# Altium schematic sheet Power.SchDoc(Power)
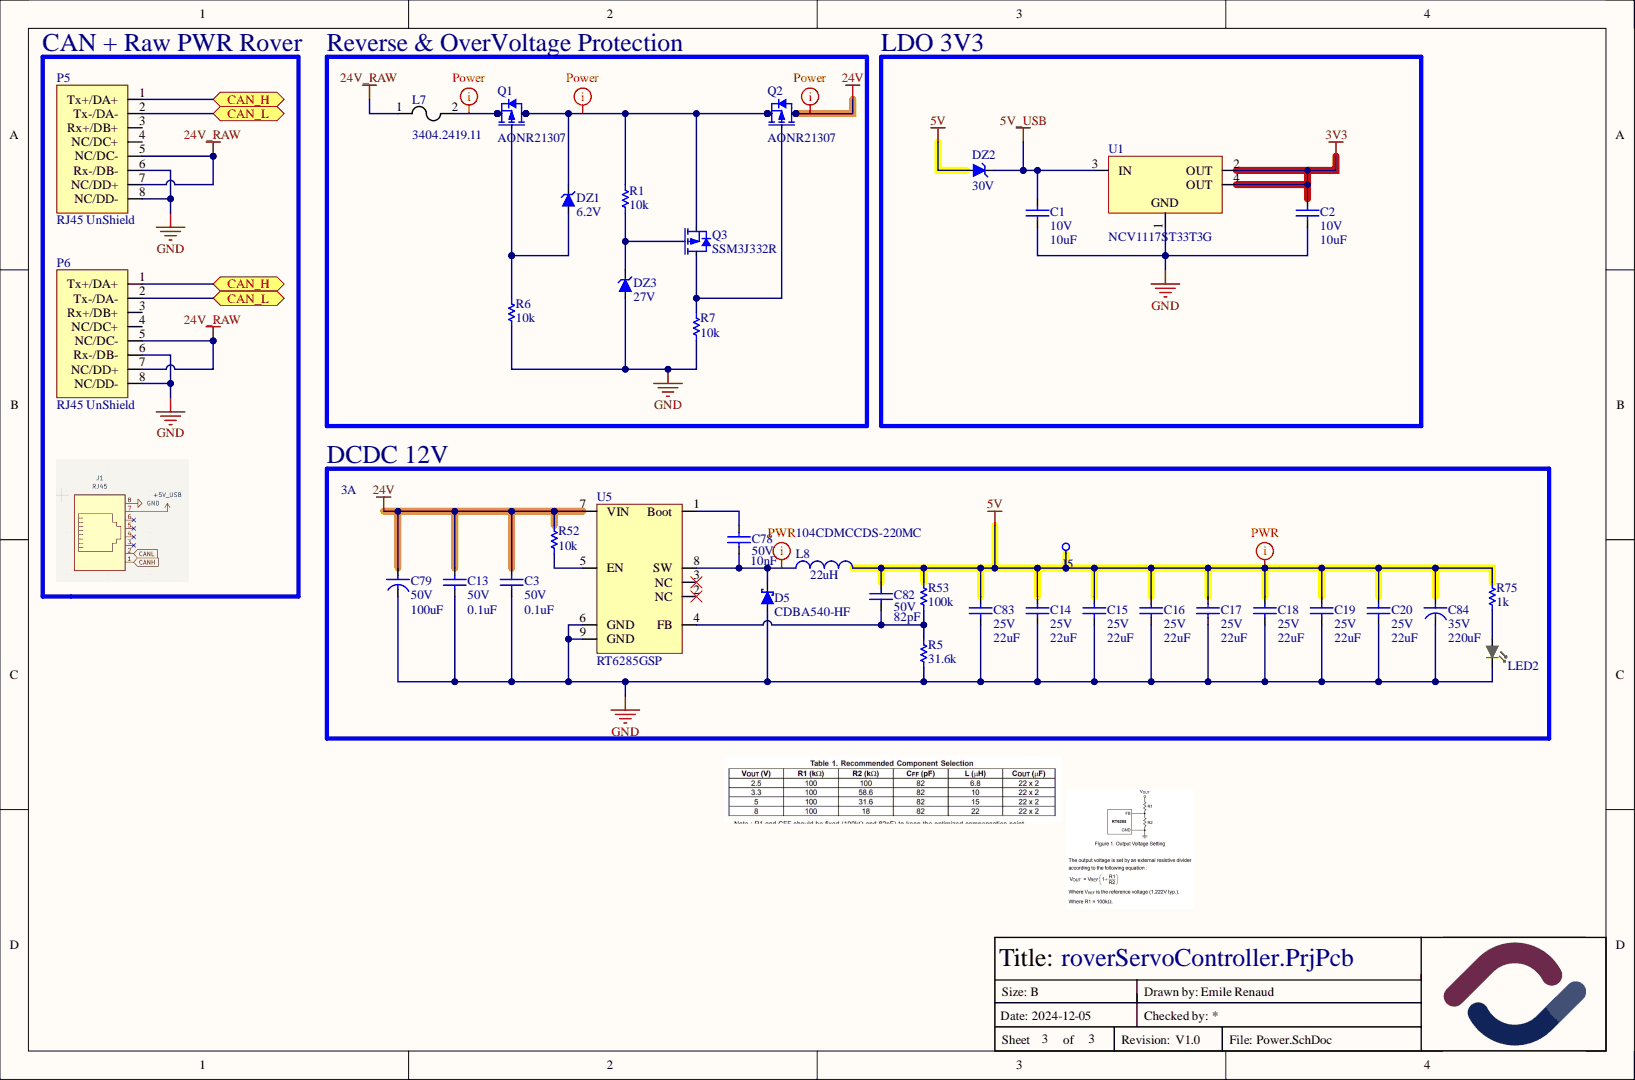
[diagram p0: Power.SchDoc]

## Parts
C1  value 10uF  Volt: 10V  [CAPACITOR-0603]  (hidden comment: Capacitor-0603-10uF-10V-X5R)
C2  value 10uF  Volt: 10V  [CAPACITOR-0603]  (hidden comment: Capacitor-0603-10uF-10V-X5R)
C3  value 0.1uF  Volt: 50V  [CAPACITOR-0603]  (hidden comment: Capacitor-0603-0.1uF-50V-X7R)
C13  value 0.1uF  Volt: 50V  [CAPACITOR-0603]  (hidden comment: Capacitor-0603-0.1uF-50V-X7R)
C14  value 22uF  Volt: 25V  [CAPACITOR-0805]  (hidden comment: Capacitor-0805-22uF-25V-X5R)
C15  value 22uF  Volt: 25V  [CAPACITOR-0805]  (hidden comment: Capacitor-0805-22uF-25V-X5R)
C16  value 22uF  Volt: 25V  [CAPACITOR-0805]  (hidden comment: Capacitor-0805-22uF-25V-X5R)
C17  value 22uF  Volt: 25V  [CAPACITOR-0805]  (hidden comment: Capacitor-0805-22uF-25V-X5R)
C18  value 22uF  Volt: 25V  [CAPACITOR-0805]  (hidden comment: Capacitor-0805-22uF-25V-X5R)
C19  value 22uF  Volt: 25V  [CAPACITOR-0805]  (hidden comment: Capacitor-0805-22uF-25V-X5R)
C20  value 22uF  Volt: 25V  [CAPACITOR-0805]  (hidden comment: Capacitor-0805-22uF-25V-X5R)
C78  value 10nF  Volt: 50V  [CAPACITOR-0603]  (hidden comment: Capacitor-0603-10nF-50V-X7R)
C79  value 100uF  Volt: 50V  [CAP_J-V_8X10P5_RUB]  (hidden comment: CAP ALUM 100UF 20% 25V SMD)
C82  value 82pF  Volt: 50V  [CAPACITOR-0603]  (hidden comment: Capacitor-0603-82pF-50V-C0G)
C83  value 22uF  Volt: 25V  [CAPACITOR-0805]  (hidden comment: Capacitor-0805-22uF-25V-X5R)
C84  value 220uF  Volt: 35V  [CAP_J-V_8X10P5_RUB]  (hidden comment: CAP ALUM 220UF 20% 35V SMD)
D5  CDBA540-HF  [SMA/DO-214AC]
DZ1  Volt: 6.2V  [SOD-123]  (hidden comment: BZT52C6V2-TP)
DZ2  Volt: 30V  [DO-219AD]  (hidden comment: MSS1P3L-M3/89A)
DZ3  Volt: 27V  [SOD-123F]  (hidden comment: MMSZ5254B-TP)
J5  hole  [HOLE-0.53MM]  (value not drawn)  (no BOM)
L7  3404.2419.11  [2-SMD-Fuse]
L8  104CDMCCDS-220MC  value 22uH  [Inductor_22UH 5A]
LED2  3147-B1911NG--20D000214U1930CT-ND  [LED-0603-2PIN-SILKSCREEN]  (value not drawn)
P5  RJ45 UnShield  [AMPHENOL_54602-908LF]
P6  RJ45 UnShield  [AMPHENOL_54602-908LF]
Q1  AONR21307  [DFN8_3x3_Power]
Q2  AONR21307  [DFN8_3x3_Power]
Q3  SSM3J332R  [SOT-23-3]
R1  value 10k  [Resistor-0603]  (hidden comment: SMT resistor)
R5  value 31.6k  [Resistor-0603]  (hidden comment: SMT resistor)
R6  value 10k  [Resistor-0603]  (hidden comment: SMT resistor)
R7  value 10k  [Resistor-0603]  (hidden comment: SMT resistor)
R52  value 10k  [Resistor-0603]  (hidden comment: SMT resistor)
R53  value 100k  [Resistor-0603]  (hidden comment: SMT resistor)
R75  value 1k  [Resistor-0603]  (hidden comment: SMT resistor)
U1  NCV1117ST33T3G  [SOT-223-3/TO-261-3S]
U5  RT6285GSP  [SOIC-8-EXPOSED-PAD]

## Ports
CAN_H, CAN_L

## Nets
3V3: C2.2 U1.2(OUT) U1.4(OUT)
5V: C14.2 C15.2 C16.2 C17.2 C18.2 C19.2 C20.2 C82.2 C83.2 C84.1 DZ2.2 J5.1 L8.2 R53.2 R75.2
5V_USB: C1.2 DZ2.1 U1.3(IN)
24V: C3.2 C13.2 C79.1 Q2.5 Q2.6 Q2.7 Q2.8 R52.2 U5.7(VIN)
24V_RAW: L7.1 P5.5(NC/DC-) P5.7(NC/DD+) P6.5(NC/DC-) P6.7(NC/DD+)
GND: C1.1 C2.1 C3.1 C13.1 C14.1 C15.1 C16.1 C17.1 C18.1 C19.1 C20.1 C79.2 C83.1 C84.2 D5.1 DZ3.1 LED2.1 P5.6(Rx-/DB-) P5.8(NC/DD-) P6.6(Rx-/DB-) P6.8(NC/DD-) R5.1 R6.1 R7.1 U1.1 U5.6(GND) U5.9(GND)
CAN_H: P5.1(Tx+/DA+) P6.1(Tx+/DA+) ; port CAN_H
CAN_L: P5.2(Tx-/DA-) P6.2(Tx-/DA-) ; port CAN_L
NetC78_1: C78.1 D5.2 L8.1 U5.8(SW)
NetC78_2: C78.2 U5.1(Boot)
NetC82_1: C82.1 R5.2 R53.1 U5.4(FB)
NetDZ1_1: DZ1.1 Q1.4 R6.2
NetDZ1_2: DZ1.2 Q1.5 Q1.6 Q1.7 Q1.8 Q2.1 Q2.2 Q2.3 Q3.2 R1.2
NetDZ3_2: DZ3.2 Q3.1 R1.1
NetL7_2: L7.2 Q1.1 Q1.2 Q1.3
NetLED2_2: LED2.2 R75.1
NetQ2_4: Q2.4 Q3.3 R7.2
NetR52_1: R52.1 U5.5(EN)

Not connected: P5 3(Rx+/DB+) 4(NC/DC+); P6 3(Rx+/DB+) 4(NC/DC+); U5 2(NC) 3(NC)

## Library data of the parts
C1 C2 = Capacitor-0603-10uF-10V-X5R: 10 µF ±20% 10V Ceramic Capacitor X5R 0603 (1608 Metric); Component Kind: Standard; Library Name: Capacitors.SchLib; Mounted: Yes; Package / Case: Metric 1608 , EIA 0603; Precision: 20%; Supplier 1: Digikey; Supplier Part Number 1: 4713-GMC10X5R106M10NTCT-ND
C3 C13 = Capacitor-0603-0.1uF-50V-X7R: Precision: X7R-10%; Component Kind: Standard; Description: Capacitor-0603-0.1uF-50V-X7R; Library Name: Capacitors.SchLib; Mounted: Yes; Package / Case: Metric 1608, EIA 0603; Supplier 1: Digi-Key; Supplier 2: Digi-Key; Supplier Part Number 1: 1276-1935-2-ND; Supplier Part Number 2: 1276-1934-2-ND
C14-C20 C83 = Capacitor-0805-22uF-25V-X5R: Precision: X5R-20%; Component Kind: Standard; Description: Capacitor-0805-22uF-25V-X5R; Library Name: Capacitors.SchLib; Mounted: Yes; Package / Case: Metric 2012 , EIA 0805; Supplier 1: Digi-Key; Supplier 2: Digi-Key; Supplier Part Number 1: 490-12389-1-ND
C78 = Capacitor-0603-10nF-50V-X7R: Precision: X7R-10%; Component Kind: Standard; Description: Capacitor-0603-10nF-50V-X7R; Library Name: Capacitors.SchLib; Mounted: Yes; Package / Case: Metric 1608 , EIA 0603; Supplier 1: Digikey; Supplier Part Number 1: 490-4778-1-ND
C79 = Capacitor-100uF-50V-SMD: CAP ALUM 100UF 20% 50V SMD; Component Kind: Standard; Library Name: Capacitors-Electrolytic.SchLib; Mounted: Yes; Precision: 20%; Supplier 1: Digi-Key; Supplier 2: Digi-Key; Supplier Part Number 1: 1189-1651-1-ND
C82 = Capacitor-0603-82pF-50V-C0G: Precision: C0G-5%; Component Kind: Standard; Description: Capacitor-0603-82pF-50V-C0G; Library Name: Capacitors.SchLib; Mounted: Yes; Package / Case: Metric 1608, EIA 0603; Supplier 1: Digi-Key; Supplier 2: Digi-Key; Supplier Part Number 1: 478-KGM15ACG1H820JTCT-ND
C84 = Capacitor-220uF-35V-SMD: Component Kind: Standard; Description: CAP ALUM 220UF 20% 35V SMD; Library Name: Capacitors-Electrolytic.SchLib; Mounted: Yes; Precision: 20%; Supplier 1: Digi-Key; Supplier 2: Digi-Key; Supplier Part Number 1: 1189-1651-1-ND
D5 = CDBA540-HF: DIODE SCHOTTKY 40V 5A SMA; Component Kind: Standard; Library Name: diodes.SchLib; Mounted: Yes; Package / Case: SMA; Supplier 1: Digi-Key; Supplier Part Number 1: 641-1707-1-ND; Volt: 40V
DZ1 = BZT52C6V2-TP: Zener Diode 6.2 V 200 mW ±6% Surface Mount SOD-123; Grade: None; Watt: 200mW; Component Kind: Standard; Library Name: diodes-zener.SchLib; Mounted: Yes; Package / Case: SOD-123; Precision: 6%; Supplier 1: Digi-Key; Supplier Part Number 1: BZT52C13-TPMSCT-ND
DZ2 = MSS1P3L-M3/89A: Diode 30 V 1A Surface Mount MicroSMP (DO-219AD); Grade: None; Component Kind: Standard; Library Name: diodes-zener.SchLib; Mounted: Yes; Package / Case: DO-214AC, SMA; Supplier 1: Digi-Key; Supplier Part Number 1: MSS1P3L-M3/89AGICT-ND
DZ3 = MMSZ5254B-TP: DIODE ZENER 27V 500MW SOD123; Grade: None; Precision: 5%; Watt: 0.5W; Component Kind: Standard; Library Name: diodes-zener.SchLib; Mounted: Yes; Package / Case: SOD-123; Supplier 1: Digi-Key; Supplier Part Number 1: MMSZ5254B-TPMSCT-ND
J5 = Hole 0.53mm AWG28-Solid: Hole for 28 AWG Solid Wire; Library Name: JumpersAndHoles.SchLib; Mounted: N/A
L7 = 3404.2419.11: 2 A 277 V AC 250 V DC Fuse Board Mount (Cartridge Style Excluded) Requires Holder, Surface Mount 2-SMD, Square End Block with Clip; Current: 2A; Component Kind: Standard; Library Name: Fuses.SchLib; Mounted: Yes; Package / Case: SMD; Supplier 1: Digi-Key; Supplier Part Number 1: 486-2091-ND
L8 = 104CDMCCDS-220MC: FIXED IND 22UH 5A 66 MOHM SMD; Precision: 20%; Component Kind: Standard; Current: 5A; Library Name: Inductors.SchLib; Mounted: Yes; Package / Case: SMD; Supplier 1: Digi-Key; Supplier Part Number 1: 308-2498-1-ND
LED2 = LED_GREEN: LED GREEN DIFFUSED 0603 SMD; Component Kind: Standard; Library Name: LEDs.SchLib; Mounted: Yes; Package / Case: 0603 (1608 Metric); Supplier 1: Digi-Key; Supplier Part Number 1: 3147-B1911NG--20D000214U1930CT-ND
P5 P6 = RJ545: Jack Modular Connector 8p8c (RJ45, Ethernet) 90° Angle (Right) Unshielded Cat3; Component Kind: Standard; HelpURL: https://cdn.amphenol-cs.com/media/wysiwyg/files/drawing/c-bmj-0102.pdf; Library Name: PC-connector.SchLib; Mounted: Yes; Package / Case: SMD; Supplier 1: Digikey; Supplier Part Number 1: 609-1046-ND; Volt: N/A
Q1 Q2 = AONR21307: MOSFET P-CH 30V 24A 8DFN; Component Kind: Standard; Current: 24A; Library Name: FET-P-Small.SchLib; Mounted: Yes; Package / Case: 8-DFN-EP (3x3); Supplier 1: Digi-Key; Supplier Part Number 1: 785-1796-2-ND; Volt: 30V
Q3 = SSM3J332R: P-Channel 30 V 6A (Ta) 1W (Ta) Surface Mount SOT-23F; Volt: 30V; Component Kind: Standard; Current: 6A; Library Name: FET-P-Small.SchLib; Mounted: Yes; Package / Case: SOT-523; Supplier 1: Digi-Key; Supplier Part Number 1: SSM3J332RLFCT-ND
R1 R6 R7 = Resistor0603-10k: Precision: 1%; Watt: 0.1W; Component Kind: Standard; Description: Resistor0603-10k; Library Name: Resistors.SchLib; Mounted: Yes; Package / Case: Metric 1608, EIA 0603; Supplier 1: Digi-Key; Supplier 2: Digi-Key; Supplier 3: Digi-Key; Supplier 4: Digi-Key; Supplier Part Number 1: 311-10.0KHRTR-ND; Supplier Part Number 2: RMCF0603FT10K0TR-ND
R5 = Resistor-0603-31.6k: Resistor0603-31.6k; Precision: 1%; Watt: 0.1W; Component Kind: Standard; Library Name: Resistors.SchLib; Mounted: Yes; Package / Case: Metric 1608, EIA 0603; Supplier 1: Digi-Key; Supplier Part Number 1: 311-31.6KHRCT-ND
R52 = Resistor-0603-10k: Resistor0603-10k; Precision: 1%; Watt: 0.1W; Component Kind: Standard; Library Name: Resistors.SchLib; Mounted: Yes; Package / Case: Metric 1608, EIA 0603; Supplier 1: Digi-Key; Supplier Part Number 1: 311-10.0KHRTR-ND
R53 = Resistor-0603-100k: Resistor0603-100k; Precision: 1%; Watt: 0.1W; Component Kind: Standard; Library Name: Resistors.SchLib; Mounted: Yes; Package / Case: Metric 1608, EIA 0603; Supplier 1: Digi-Key; Supplier Part Number 1: 311-100KHRCT-ND
R75 = Resistor-0603-1k: Resistor0603-1k; Precision: 1%; Watt: 0.1W; Component Kind: Standard; Library Name: Resistors.SchLib; Mounted: Yes; Package / Case: Metric 1608, EIA 0603; Supplier 1: Digi-Key; Supplier Part Number 1: 311-1.00KHRCT-ND
U1 = NCV1117ST33T3G: IC REG LINEAR 3.3V 1A SOT223; Component Kind: Standard; Library Name: PMIC-VoltageRegulators-LinearRegulators.SchLib; Mounted: Yes; Package / Case: SOT223; Supplier 1: Digi-Key; Supplier Part Number 1: NCP1117ST15T3GOSTR-ND
U5 = RT6285GSP: 5A Buck Switching Regulator IC Positive Adjustable 1.222V 1 Output 5A 8-SOIC (0.154", 3.90mm Width) Exposed Pad; Grade: None; Component Kind: Standard; Library Name: PMIC-VoltageRegulators-DCDCSwitchingRegulators.SchLib; Mounted: Yes; Package / Case: 8-SOIC-EP; Supplier 1: Digi-Key; Supplier Part Number 1: 1028-RT6285GSPCT-ND; HelpURL: file:///C:/Users/Emile/Downloads/DS6285-00.pdf
